# Altium schematic sheet MCU045A_ezFET_Host.SchDoc(MCU045A_ezFET_Host)
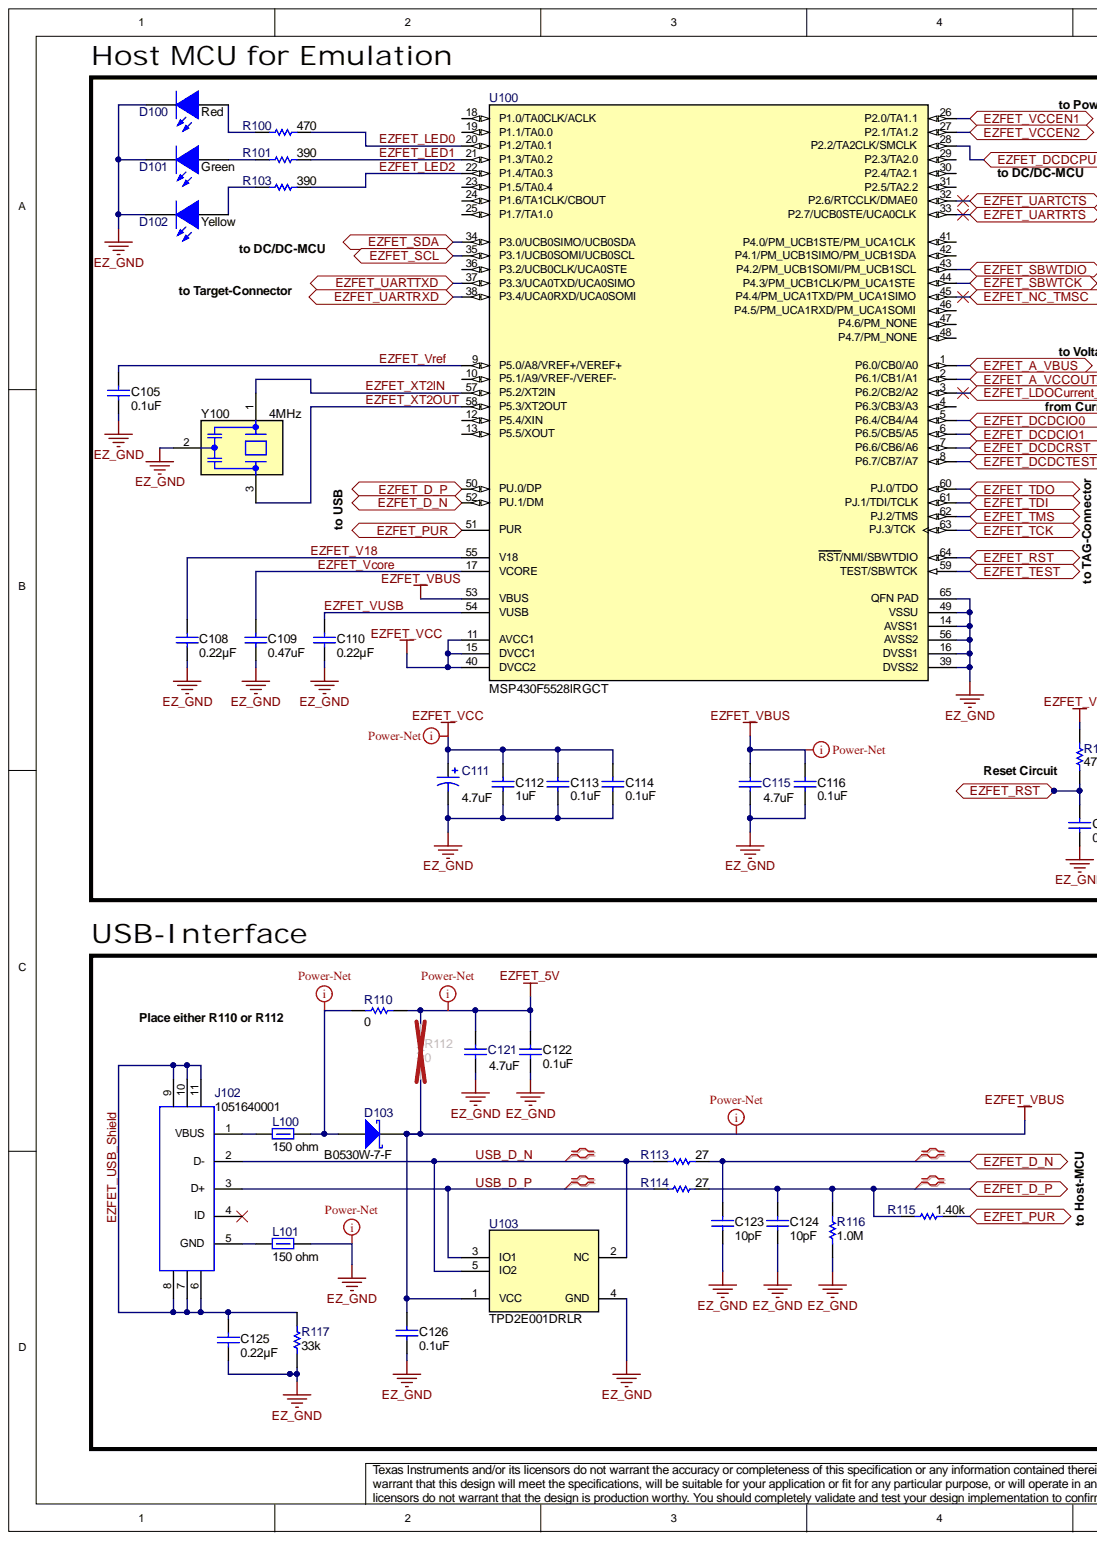
[diagram p0: MCU045A_ezFET_Host.SchDoc, left]
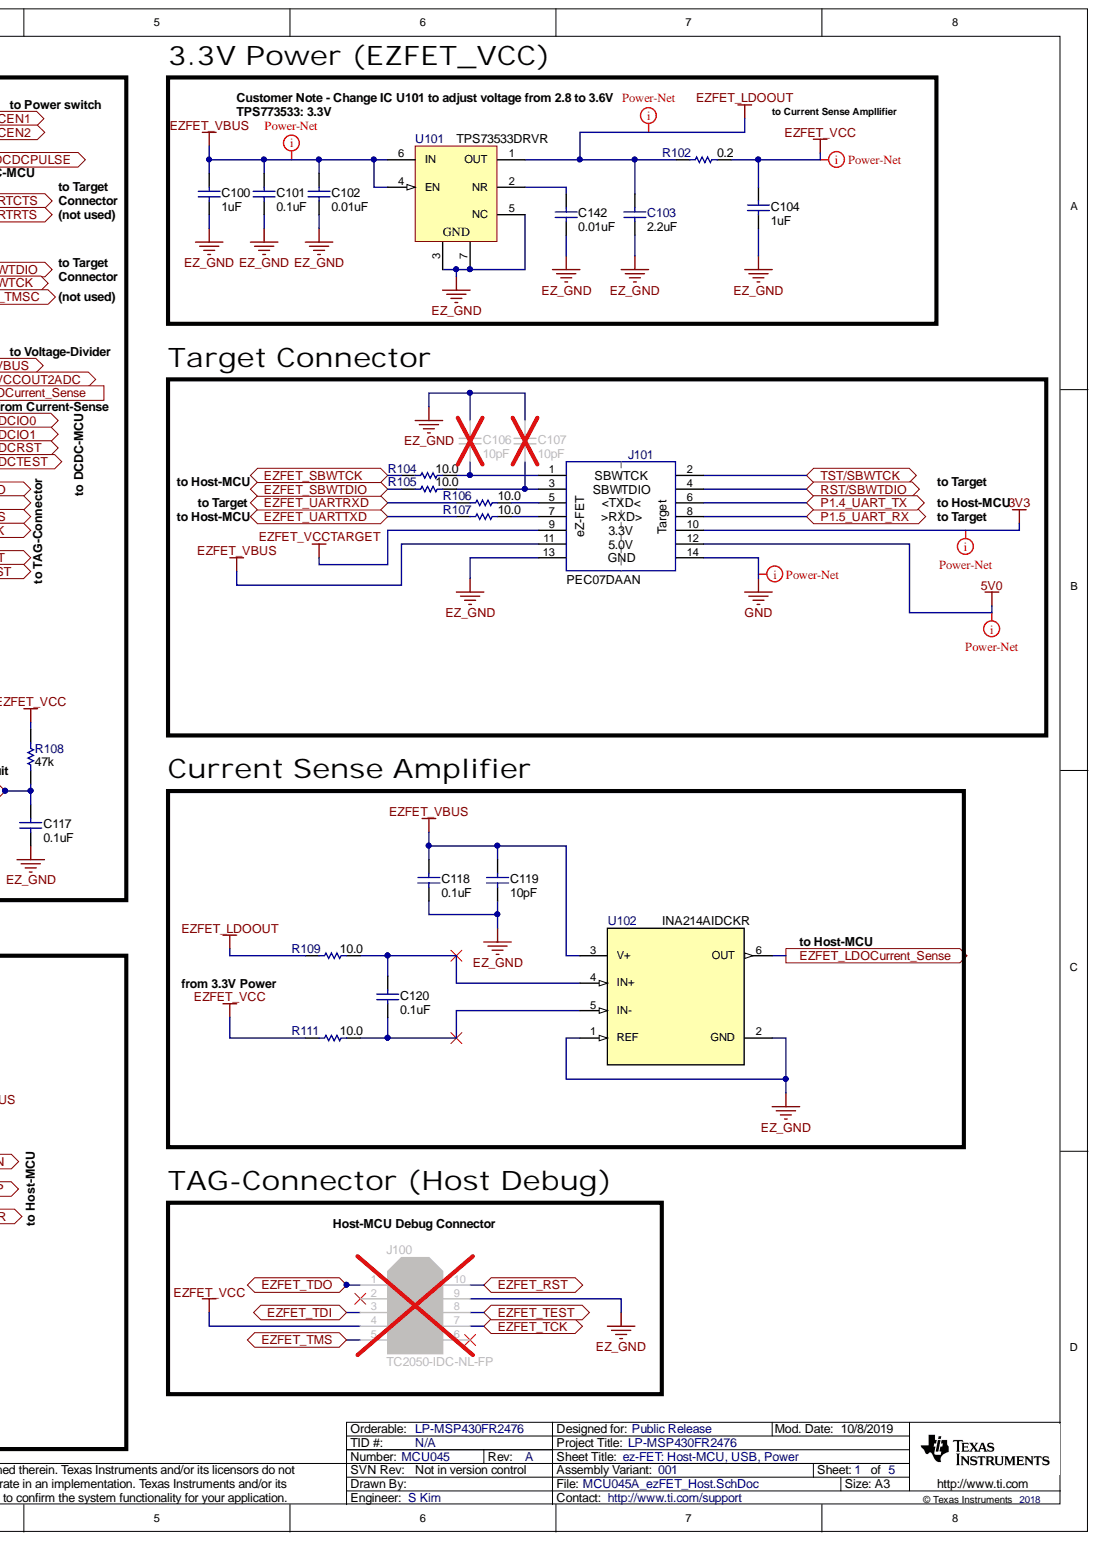
[diagram p1: MCU045A_ezFET_Host.SchDoc, right]

## Parts
C100  value 1uF  ValueDisplayed: 1uF  [0402]  (hidden comment: GRM152R60J105ME15D)
C101  value 0.1uF  ValueDisplayed: 0.1uF  [0402]  (hidden comment: GCM155R71C104KA55D)
C102  value 0.01uF  ValueDisplayed: 0.01uF  [0402]  (hidden comment: 0402ZC103KAT2A)
C103  value 2.2uF  ValueDisplayed: 2.2uF  [0402]  (hidden comment: GRM155R61C225KE11D)
C104  value 1uF  ValueDisplayed: 1uF  [0402]  (hidden comment: GRM152R60J105ME15D)
C105  value 0.1uF  ValueDisplayed: 0.1uF  [0402]  (hidden comment: GCM155R71C104KA55D)
C106  value 10pF  ValueDisplayed: 10pF  [0402]  (hidden comment: 885012005055)
C107  value 10pF  ValueDisplayed: 10pF  [0402]  (hidden comment: 885012005055)
C108  ValueDisplayed: 0.22µF  [0402]  (hidden comment: 885012105011)
C109  value 0.47uF  ValueDisplayed: 0.47uF  [0402]  (hidden comment: 04026D474KAT2A)
C110  ValueDisplayed: 0.22µF  [0402]  (hidden comment: 885012105011)
C111  value 4.7uF  ValueDisplayed: 4.7uF  [3216-18]  (hidden comment: 293D475X9010A2TE3)
C112  value 1uF  ValueDisplayed: 1uF  [0402]  (hidden comment: GRM152R60J105ME15D)
C113  value 0.1uF  ValueDisplayed: 0.1uF  [0402]  (hidden comment: GCM155R71C104KA55D)
C114  value 0.1uF  ValueDisplayed: 0.1uF  [0402]  (hidden comment: GCM155R71C104KA55D)
C115  value 4.7uF  ValueDisplayed: 4.7uF  [0402_065]  (hidden comment: GRM155R60J475ME87D)
C116  value 0.1uF  ValueDisplayed: 0.1uF  [0402]  (hidden comment: GCM155R71C104KA55D)
C117  value 0.1uF  ValueDisplayed: 0.1uF  [0402]  (hidden comment: GMK105BJ104KV-F)
C118  value 0.1uF  ValueDisplayed: 0.1uF  [0402]  (hidden comment: GCM155R71C104KA55D)
C119  value 10pF  ValueDisplayed: 10pF  [0402]  (hidden comment: 885012005055)
C120  value 0.1uF  ValueDisplayed: 0.1uF  [0402]  (hidden comment: GMK105BJ104KV-F)
C121  value 4.7uF  ValueDisplayed: 4.7uF  [0805_HV]  (hidden comment: C0805C475K8PACTU)
C122  value 0.1uF  ValueDisplayed: 0.1uF  [0402]  (hidden comment: GCM155R71C104KA55D)
C123  value 10pF  ValueDisplayed: 10pF  [0402]  (hidden comment: 885012005055)
C124  value 10pF  ValueDisplayed: 10pF  [0402]  (hidden comment: 885012005055)
C125  ValueDisplayed: 0.22µF  [0402]  (hidden comment: 885012105011)
C126  value 0.1uF  ValueDisplayed: 0.1uF  [0402]  (hidden comment: GCM155R71C104KA55D)
C142  value 0.01uF  ValueDisplayed: 0.01uF  [0402]  (hidden comment: 0402ZC103KAT2A)
D100  value Red  [WL-SMCW_RED]  (hidden comment: 150060RS75000)
D101  value Green  [WL-SMCW_GREEN]  (hidden comment: 150060VS75000)
D102  value Yellow  [WL-SMCW_YELLOW]  (hidden comment: 150060YS75000)
D103  B0530W-7-F  [SOD-123]
J100  TC2050-IDC-NL-FP  [Tag_TC2050-NL]  (no BOM)
J101  PEC07DAAN  [PEC07DAAN_Launchpad_EZ-FET_A]
J102  1051640001  [Molex_1051640001]
L100  150 ohm  [0603]
L101  150 ohm  [0603]
R100  value 470  [0402]  (hidden comment: CRCW0402470RJNED)
R101  value 390  [0402]  (hidden comment: CRCW0402390RJNED)
R102  value 0.2  ValueDisplayed: 0.2  [0603]  (hidden comment: CSR0603FKR200)
R103  value 390  [0402]  (hidden comment: CRCW0402390RJNED)
R104  value 10.0  ValueDisplayed: 10.0  [0402]  (hidden comment: CRCW040210R0FKED)
R105  value 10.0  ValueDisplayed: 10.0  [0402]  (hidden comment: CRCW040210R0FKED)
R106  value 10.0  ValueDisplayed: 10.0  [0402]  (hidden comment: CRCW040210R0FKED)
R107  value 10.0  ValueDisplayed: 10.0  [0402]  (hidden comment: CRCW040210R0FKED)
R108  value 47k  ValueDisplayed: 47k  [0402]  (hidden comment: CRCW040247K0JNED)
R109  value 10.0  ValueDisplayed: 10.0  [0402]  (hidden comment: CRCW040210R0FKED)
R110  value 0  [1206]  (hidden comment: CRCW12060000Z0EA)
R111  value 10.0  ValueDisplayed: 10.0  [0402]  (hidden comment: CRCW040210R0FKED)
R112  value 0  [1206]  (hidden comment: CRCW12060000Z0EA)
R113  value 27  [0402]  (hidden comment: CRCW040227R0JNED)
R114  value 27  [0402]  (hidden comment: CRCW040227R0JNED)
R115  value 1.40k  ValueDisplayed: 1.40k  [0402]  (hidden comment: CRCW04021K40FKED)
R116  ValueDisplayed: 1.0M  [0402]  (hidden comment: CRCW04021M00JNED)
R117  value 33k  ValueDisplayed: 33k  [0402]  (hidden comment: CRCW040233K0JNED)
U100  MSP430F5528IRGCT  [RGC0064B]
U101  TPS73533DRVR  [DRV0006A]
U102  INA214AIDCKR  [DCK0006A_N]
U103  TPD2E001DRLR  [DRL0005A]
Y100  Frequency_Fixed: 4MHz  [Murata_CSTCR_G]  (hidden comment: CSTCR4M00G55B-R0)

## Ports
EZFET_A_VBUS, EZFET_A_VCCOUT2ADC, EZFET_D_N, EZFET_D_P, EZFET_DCDCIO0, EZFET_DCDCIO1, EZFET_DCDCPULSE, EZFET_DCDCRST, EZFET_DCDCTEST, EZFET_LDOCurrent_Sense, EZFET_NC_TMSC, EZFET_PUR, EZFET_RST, EZFET_SBWTCK, EZFET_SBWTDIO, EZFET_SCL, EZFET_SDA, EZFET_TCK, EZFET_TDI, EZFET_TDO, EZFET_TEST, EZFET_TMS, EZFET_UARTCTS, EZFET_UARTRTS, EZFET_UARTRXD, EZFET_UARTTXD, EZFET_VCCEN1, EZFET_VCCEN2, P1.4_UART_TX, P1.5_UART_RX, RST/SBWTDIO, TST/SBWTCK

## Nets
3V3: J101.10
5V0: J101.12
EZ_GND: C100.2 C101.2 C102.2 C103.2 C104.2 C105.2 C106.1 C107.1 C108.2 C109.1 C110.2 C111.2 C112.2 C113.2 C114.2 C115.2 C116.2 C117.2 C118.2 C119.2 C121.2 C122.2 C123.2 C124.2 C125.2 C126.2 C142.2 D100.1 D101.1 D102.1 J100.9 J101.13 L101.2 R116.1 R117.1 U100.14(AVSS1) U100.16(DVSS1) U100.39(DVSS2) U100.49(VSSU) U100.56(AVSS2) U100.65(QFN_PAD) U101.3 U101.5(NC) U101.7 U102.1(REF) U102.2(GND) U103.4(GND) Y100.2
EZFET_5V: C121.1 C122.1 R110.1 R112.2
EZFET_LDOOUT: C103.1 R102.1 R109.1 U101.1(OUT)
EZFET_VBUS: C100.1 C101.1 C102.1 C115.1 C116.1 C118.1 C119.1 C126.1 D103.1 J101.11 R112.1 U100.53(VBUS) U101.4(EN) U101.6(IN) U102.3(V+) U103.1(VCC)
EZFET_VCC: C104.1 C111.1 C112.1 C113.1 C114.1 J100.4 R102.2 R108.2 R111.1 U100.11(AVCC1) U100.15(DVCC1) U100.40(DVCC2)
EZFET_VCCTARGET: J101.9
GND: J101.14
EZFET_A_VBUS: U100.1(P6.0/CB0/A0) ; port EZFET_A_VBUS
EZFET_A_VCCOUT2ADC: U100.2(P6.1/CB1/A1) ; port EZFET_A_VCCOUT2ADC
EZFET_D_N: C123.1 R113.2 U100.52(PU.1/DM) ; port EZFET_D_N
EZFET_D_P: C124.1 R114.2 R115.1 R116.2 U100.50(PU.0/DP) ; port EZFET_D_P
EZFET_DCDCIO0: U100.5(P6.4/CB4/A4) ; port EZFET_DCDCIO0
EZFET_DCDCIO1: U100.6(P6.5/CB5/A5) ; port EZFET_DCDCIO1
EZFET_DCDCPULSE: U100.28(P2.2/TA2CLK/SMCLK) ; port EZFET_DCDCPULSE
EZFET_DCDCRST: U100.7(P6.6/CB6/A6) ; port EZFET_DCDCRST
EZFET_DCDCTEST: U100.8(P6.7/CB7/A7) ; port EZFET_DCDCTEST
EZFET_LDOCurrent_Sense: U100.3(P6.2/CB2/A2) U102.6(OUT) ; port EZFET_LDOCurrent_Sense
EZFET_LED0: R100.2 U100.20(P1.2/TA0.1)
EZFET_LED1: R101.2 U100.21(P1.3/TA0.2)
EZFET_LED2: R103.2 U100.22(P1.4/TA0.3)
EZFET_NC_TMSC: U100.45(P4.4/PM_UCA1TXD/PM_UCA1SIMO) ; port EZFET_NC_TMSC
EZFET_PUR: R115.2 U100.51(PUR) ; port EZFET_PUR
EZFET_RST: C117.1 J100.10 R108.1 U100.64(RST/NMI/SBWTDIO) ; port EZFET_RST
EZFET_SBWTCK: R104.1 U100.44(P4.3/PM_UCB1CLK/PM_UCA1STE) ; port EZFET_SBWTCK
EZFET_SBWTDIO: R105.1 U100.43(P4.2/PM_UCB1SOMI/PM_UCB1SCL) ; port EZFET_SBWTDIO
EZFET_SCL: U100.35(P3.1/UCB0SOMI/UCB0SCL) ; port EZFET_SCL
EZFET_SDA: U100.34(P3.0/UCB0SIMO/UCB0SDA) ; port EZFET_SDA
EZFET_TCK: J100.7 U100.63(PJ.3/TCK) ; port EZFET_TCK
EZFET_TDI: J100.3 U100.61(PJ.1/TDI/TCLK) ; port EZFET_TDI
EZFET_TDO: J100.1 U100.60(PJ.0/TDO) ; port EZFET_TDO
EZFET_TEST: J100.8 U100.59(TEST/SBWTCK) ; port EZFET_TEST
EZFET_TMS: J100.5 U100.62(PJ.2/TMS) ; port EZFET_TMS
EZFET_UARTCTS: U100.32(P2.6/RTCCLK/DMAE0) ; port EZFET_UARTCTS
EZFET_UARTRTS: U100.33(P2.7/UCB0STE/UCA0CLK) ; port EZFET_UARTRTS
EZFET_UARTRXD: R106.2 U100.38(P3.4/UCA0RXD/UCA0SOMI) ; port EZFET_UARTRXD
EZFET_UARTTXD: R107.1 U100.37(P3.3/UCA0TXD/UCA0SIMO) ; port EZFET_UARTTXD
EZFET_USB_Shield: C125.1 J102.6 J102.7 J102.8 J102.9 J102.10 J102.11 R117.2
EZFET_V18: C108.1 U100.55(V18)
EZFET_VCCEN1: U100.26(P2.0/TA1.1) ; port EZFET_VCCEN1
EZFET_VCCEN2: U100.27(P2.1/TA1.2) ; port EZFET_VCCEN2
EZFET_Vcore: C109.2 U100.17(VCORE)
EZFET_Vref: C105.1 U100.9(P5.0/A8/VREF+/VEREF+)
EZFET_VUSB: C110.1 U100.54(VUSB)
EZFET_XT2IN: U100.57(P5.2/XT2IN) Y100.1
EZFET_XT2OUT: U100.58(P5.3/XT2OUT) Y100.3
P1.4_UART_TX: J101.6 ; port P1.4_UART_TX
P1.5_UART_RX: J101.8 ; port P1.5_UART_RX
RST/SBWTDIO: J101.4(SBWTDIO) ; port RST/SBWTDIO
TST/SBWTCK: J101.2 ; port TST/SBWTCK
USB_D_N: J102.2(D-) R113.1 U103.2(NC) U103.5(IO2)
USB_D_P: J102.3(D+) R114.1 U103.3(IO1)
NetC106_2: C106.2 J101.1 R104.2
NetC107_2: C107.2 J101.3 R105.2
NetC120_1: C120.1 R109.2 U102.4(IN+)
NetC120_2: C120.2 R111.2 U102.5(IN-)
NetC142_1: C142.1 U101.2(NR)
NetD100_2: D100.2 R100.1
NetD101_2: D101.2 R101.1
NetD102_2: D102.2 R103.1
NetD103_2: D103.2 L100.2 R110.2
NetJ101_5: J101.5 R106.1
NetJ101_7: J101.7 R107.2
NetJ102_1: J102.1(VBUS) L100.1
NetJ102_5: J102.5(GND) L101.1

Not connected: J100 2 6; J102 4(ID); U100 4(P6.3/CB3/A3) 10(P5.1/A9/VREF-/VEREF-) 12(P5.4/XIN) 13(P5.5/XOUT) 18(P1.0/TA0CLK/ACLK) 19(P1.1/TA0.0) 23(P1.5/TA0.4) 24(P1.6/TA1CLK/CBOUT) 25(P1.7/TA1.0) 29(P2.3/TA2.0) 30(P2.4/TA2.1) 31(P2.5/TA2.2) 36(P3.2/UCB0CLK/UCA0STE) 41(P4.0/PM_UCB1STE/PM_UCA1CLK) 42(P4.1/PM_UCB1SIMO/PM_UCB1SDA) 46(P4.5/PM_UCA1RXD/PM_UCA1SOMI) 47(P4.6/PM_NONE) 48(P4.7/PM_NONE)

## Library data of the parts
C100 C104 C112 = CMP-0006372-2: CAP, CERM, 1 uF, 6.3 V, +/- 20%, X5R, 0402; Manufacturer: MuRata; VDC_V: 6.3V; Value1: Unknown; U/M: EA; TaMin_C: -55°C; TaMax_C: 85°C; SizeZ_mm: 0.6; SizeY_mm: 0.5; SizeX_mm: 1; SizeAreaWB_mm2: 3; Polarized: N; CompType: Capacitor; Cap_F: 1µF; AEC Category: Q200; Value2: 6.3V; Tolerance_%: 20%; TempCo: X5R; Technology: Ceramic; Supplier Part Number 2: 81-GRM152R60J105ME5D; Supplier Part Number 1: 490-10006-1-ND; Supplier 2: Mouser; Supplier 1: Digi-Key; SizeArea_mm2: 0.5; RoHS: Y; Published: 2008-06-19; PartNumber: GRM152R60J105ME15D; PackageReference: 0402; MountType: SMT; Library Name: Altium Vault - TI; LatestRevisionDate: 2017-03-09; ComponentLink1URL: http://psearch.murata.com/capacitor/product/GRM152R60J105ME15%23.pdf; ComponentLink1Description: Datasheet link; Component Kind: Standard; Cap_Case: 0402; AEC Qualified: ?; Publisher: Texas Instruments
C101 C105 C113 C114 C116 C118 C122 C126 = CMP-0006351-2: CAP, CERM, 0.1 uF, 16 V, +/- 10%, X7R, 0402; Manufacturer: MuRata; VDC_V: 16V; Value1: Unknown; U/M: EA; TaMin_C: -55°C; TaMax_C: 125°C; SizeZ_mm: 0.6; SizeY_mm: 0.5; SizeX_mm: 1; SizeAreaWB_mm2: 3; Polarized: N; CompType: Capacitor; Cap_F: 100nF; AEC Category: Q200; Value2: 16V; Tolerance_%: 10%; TempCo: X7R; Technology: Ceramic; Supplier Part Number 1: 490-4759-2-ND; Supplier 1: Digi-Key; SizeArea_mm2: 0.5; RoHS: Y; Published: 2008-06-19; PartNumber: GCM155R71C104KA55D; PackageReference: 0402; MountType: SMT; Library Name: Altium Vault - TI; LatestRevisionDate: 2017-03-09; ComponentLink1URL: http://psearch.murata.com/capacitor/product/GCM155R71C104KA55%23.pdf; ComponentLink1Description: Datasheet link; Component Kind: Standard; Cap_Case: 0402; AEC Qualified: ?; Publisher: Texas Instruments
C102 C142 = CMP-0006203-2: CAP, CERM, 0.01 uF, 10 V, +/- 10%, X7R, 0402; Manufacturer: AVX; VDC_V: 10V; Value1: Unknown; U/M: EA; TaMin_C: -55°C; TaMax_C: 125°C; SizeZ_mm: 0.6; SizeY_mm: 0.5; SizeX_mm: 1; SizeAreaWB_mm2: 3; Polarized: N; CompType: Capacitor; Cap_F: 10nF; AEC Category: Q200; Value2: 10V; Tolerance_%: 10%; TempCo: X7R; Technology: Ceramic; Supplier Part Number 3: 581-0402ZC103KAT2A; Supplier Part Number 2: 94W2829; Supplier Part Number 1: 478-7890-1-ND; Supplier 3: Mouser; Supplier 2: Newark; Supplier 1: Digi-Key; SizeArea_mm2: 0.5; RoHS: Y; Published: 2008-06-19; PartNumber: 0402ZC103KAT2A; PackageReference: 0402; MountType: SMT; Library Name: Altium Vault - TI; LatestRevisionDate: 2017-03-09; ComponentLink1URL: http://datasheets.avx.com/X7RDielectric.pdf; ComponentLink1Description: Datasheet link; Component Kind: Standard; Cap_Case: 0402; AEC Qualified: N; AEC Grade: N/A; Publisher: Texas Instruments
C103 = CMP-0006594-2: CAP, CERM, 2.2 uF, 16 V, +/- 10%, X5R, 0402; Manufacturer: MuRata; VDC_V: 16V; Value1: Unknown; U/M: EA; TaMin_C: -55°C; TaMax_C: 85°C; SizeZ_mm: 0.6; SizeY_mm: 0.5; SizeX_mm: 1; SizeAreaWB_mm2: 3; Polarized: N; CompType: Capacitor; Cap_F: 2.2µF; AEC Category: Q200; Value2: 16V; Tolerance_%: 10%; TempCo: X5R; Technology: Ceramic; Supplier Part Number 2: 81-GRM155R61C225KE1D; Supplier Part Number 1: 490-10695-1-ND; Supplier 2: Mouser; Supplier 1: Digi-Key; SizeArea_mm2: 0.5; RoHS: Y; Published: 2008-06-19; PartNumber: GRM155R61C225KE11D; PackageReference: 0402; MountType: SMT; Library Name: Altium Vault - TI; LatestRevisionDate: 2017-03-09; ComponentLink1URL: http://psearch.en.murata.com/capacitor/product/GRM155R61C225KE11%23.pdf; ComponentLink1Description: Datasheet link; Component Kind: Standard; Cap_Case: 0402; AEC Qualified: N; AEC Grade: N/A; Publisher: Texas Instruments
C106 C107 C119 C123 C124 = CMP-0006215-2: CAP, CERM, 10 pF, 50 V, +/- 5%, C0G/NP0, 0402; Manufacturer: Wurth Elektronik; VDC_V: 50V; Value1: Unknown; U/M: EA; TaMin_C: -55°C; TaMax_C: 125°C; SizeZ_mm: 0.6; SizeY_mm: 0.5; SizeX_mm: 1; SizeAreaWB_mm2: 3; Polarized: N; CompType: Capacitor; AEC Category: Q200; Value2: 50V; Tolerance_%: 5%; TempCo: C0G/NP0; Technology: Ceramic; Supplier Part Number 2: 710-885012005055; Supplier Part Number 1: 732-7469-1-ND; Supplier 2: Mouser; Supplier 1: Digi-Key; SizeArea_mm2: 0.5; RoHS: Y; Published: 2008-06-19; PartNumber: 885012005055; PackageReference: 0402; MountType: SMT; Library Name: Altium Vault - TI; LatestRevisionDate: 2017-03-09; ComponentLink1URL: http://katalog.we-online.de/pbs/datasheet/885012005055.pdf; ComponentLink1Description: Datasheet link; Component Kind: Standard; Cap_F: 10pF; Cap_Case: 0402; AEC Qualified: N; AEC Grade: N/A; Publisher: Texas Instruments
C108 C110 C125 = CMP-0078875-1: CAP, CERM, 0.22 µF, 10 V,+/- 20%, X5R, 0402; Manufacturer: Wurth Elektronik; VDC_V: 10V; Value: 0.22uF; U/M: EA; TaMin_C: -55°C; TaMax_C: 85°C; SizeZ_mm: 0.6; SizeY_mm: 0.5; SizeX_mm: 1; SizeAreaWB_mm2: 3; Polarized: N; CompType: Capacitor; Cap_F: 220nF; AEC Category: Q200; Value2: 10V; Tolerance_%: 20%; TempCo: X5R; Technology: Ceramic; Supplier Part Number 2: 710-885012105011; Supplier Part Number 1: 732-7488-1-ND; Supplier 2: Mouser; Supplier 1: Digi-Key; SizeArea_mm2: 0.5; RoHS: Y; PartNumber: 885012105011; PackageReference: 0402; MountType: SMT; Library Name: Altium Vault - TI; ComponentLink1URL: http://katalog.we-online.de/pbs/datasheet/885012105011.pdf; ComponentLink1Description: Datasheet link; Component Kind: Standard; Cap_Case: 0402; AEC Qualified: N; AEC Grade: N/A
C109 = CMP-0006199-2: CAP, CERM, 0.47 uF, 6.3 V, +/- 10%, X5R, 0402; Manufacturer: AVX; VDC_V: 6.3V; Value1: Unknown; U/M: EA; TaMin_C: -55°C; TaMax_C: 85°C; SizeZ_mm: 0.6; SizeY_mm: 0.5; SizeX_mm: 1; SizeAreaWB_mm2: 3; Polarized: N; CompType: Capacitor; Cap_F: 470nF; AEC Category: Q200; Value2: 6.3V; Tolerance_%: 10%; TempCo: X5R; Technology: Ceramic; Supplier Part Number 3: 581-04026D474KAT2A; Supplier Part Number 2: 60R6163; Supplier Part Number 1: 478-6196-1-ND; Supplier 3: Mouser; Supplier 2: Newark; Supplier 1: Digi-Key; SizeArea_mm2: 0.5; RoHS: Y; Published: 2008-06-19; PartNumber: 04026D474KAT2A; PackageReference: 0402; MountType: SMT; Library Name: Altium Vault - TI; LatestRevisionDate: 2017-03-09; ComponentLink1URL: http://catalogs.avx.com/SurfaceMount.pdf; ComponentLink1Description: Datasheet link; Component Kind: Standard; Cap_Case: 0402; AEC Qualified: N; AEC Grade: N/A; Publisher: Texas Instruments
C111 = CMP-0011459-2: CAP, TA, 4.7 uF, 10 V, +/- 10%, 5 ohm, SMD; Manufacturer: Vishay-Sprague; VDC_V: 10V; Value1: 5 ohm; U/M: EA; TaMin_C: -55°C; TaMax_C: 125°C; SizeZ_mm: 1.8; SizeY_mm: 1.6; SizeX_mm: 3.2; SizeAreaWB_mm2: 10.92; SizeArea_mm2: 5.12; Polarized: Y; IRMS_A: 1.2dA; ESR_ohm: 5; CompType: Capacitor; Cap_F: 4.7µF; Cap_Case: A; AEC Category: Q200; Value2: 10V; Tolerance_%: 10%; Technology: TA; Supplier Part Number 3: 197397; Supplier Part Number 2: 74-293D475X9010A2TE3; Supplier Part Number 1: 718-1146-1-ND; Supplier 3: Farnell; Supplier 2: Mouser; Supplier 1: Digi-Key; Series: 293D; RoHS: Y; PartNumber: 293D475X9010A2TE3; PackageReference: 3216-18; MountType: SMT; Library Name: Altium Vault - TI; LatestRevisionNote: Updated supplier links; LatestRevisionDate: 2017-03-09; ComponentLink1URL: http://www.vishay.com/docs/40002/293d.pdf; ComponentLink1Description: Datasheet link; Component Kind: Standard; AEC Qualified: ?; Publisher: Texas Instruments
C115 = CMP-0006553-3: CAP, CERM, 4.7 uF, 6.3 V, +/- 20%, X5R, 0402; Manufacturer: MuRata; VDC_V: 6.3V; Value1: 0.009 ohm; U/M: EA; TaMin_C: -55°C; TaMax_C: 85°C; SizeZ_mm: 0.6; SizeY_mm: 0.5; SizeX_mm: 1; SizeAreaWB_mm2: 3; Polarized: N; IRMS_A: 1.22A; Green_REACh: Incomplete; Green_Lifecycle: Active; ESR_ohm: 9m; CompType: Capacitor; Cap_F: 4.7µF; Cap_Case: 0402; AEC Category: Q200; Value2: 6.3V; Tolerance_%: 20%; TempCo: X5R; Technology: Ceramic; Supplier Part Number 1: 490-5408-1-ND; Supplier 1: Digi-Key; SizeArea_mm2: 0.5; RoHS: Y; PartNumber: GRM155R60J475ME87D; PackageReference: 0402; MountType: SMT; Library Name: Altium Vault - TI; Green_EU RoHS-2: Incomplete; Green_EU RoHS: Passed; ComponentLink1URL: http://www.murata.com/products/catalog/pdf/c02e.pdf; ComponentLink1Description: Datasheet link; Component Kind: Standard; AEC Qualified: N; AEC Grade: N/A
C117 C120 = CMP-0006370-2: CAP, CERM, 0.1 uF, 35 V, +/- 10%, X5R, 0402; Manufacturer: Taiyo Yuden; VDC_V: 35V; Value1: Unknown; U/M: EA; TaMin_C: -55°C; TaMax_C: 85°C; SizeZ_mm: 0.6; SizeY_mm: 0.5; SizeX_mm: 1; SizeAreaWB_mm2: 3; Polarized: N; CompType: Capacitor; Cap_F: 100nF; AEC Category: Q200; Value2: 35V; Tolerance_%: 10%; TempCo: X5R; Technology: Ceramic; Supplier Part Number 3: 963-GMK105BJ104KV-F; Supplier Part Number 2: 84R9117; Supplier Part Number 1: 587-1994-1-ND; Supplier 3: Mouser; Supplier 2: Newark; Supplier 1: Digi-Key; SizeArea_mm2: 0.5; RoHS: Y; Published: 2008-06-19; PartNumber: GMK105BJ104KV-F; PackageReference: 0402; MountType: SMT; Library Name: Altium Vault - TI; LatestRevisionDate: 2017-03-09; ComponentLink1URL: http://www.yuden.co.jp/productdata/catalog/en/mlcc_all_e.pdf; ComponentLink1Description: Datasheet link; Component Kind: Standard; Cap_Case: 0402; AEC Qualified: N; AEC Grade: N/A; Publisher: Texas Instruments
C121 = CMP-0007801-2: CAP, CERM, 4.7 uF, 10 V, +/- 10%, X5R, 0805; Manufacturer: Kemet; VDC_V: 10V; Value1: 0.004 ohm; U/M: EA; TaMin_C: -55°C; TaMax_C: 85°C; SizeZ_mm: 1.45; SizeY_mm: 1.25; SizeX_mm: 2; SizeAreaWB_mm2: 6.75; SizeArea_mm2: 2.5; Polarized: N; IRMS_A: 9.89A; ESR_ohm: 4m; CompType: Capacitor; Cap_F: 4.7µF; Cap_Case: 0805; AEC Category: Q200; Value2: 10V; Tolerance_%: 10%; TempCo: X5R; Technology: Ceramic; Supplier Part Number 3: 80-C0805C475K8P; Supplier Part Number 2: 70K9144; Supplier Part Number 1: 399-3133-1-ND; Supplier 3: Mouser; Supplier 2: Newark; Supplier 1: Digi-Key; RoHS: Y; Published: 2008-06-19; PartNumber: C0805C475K8PACTU; PackageReference: 0805; MountType: SMT; Library Name: Altium Vault - TI; LatestRevisionDate: 2017-03-09; ComponentLink1URL: http://www.kemet.com/kemet/web/homepage/kechome.nsf/file/Partial%20Copy%20-%20Ceramic%20Surface%20Mount%20Capacitors/$file/F3102_CE.pdf; ComponentLink1Description: Datasheet link; Component Kind: Standard; AEC Qualified: ?; Publisher: Texas Instruments
D100 = CMP-0002947-2: LED, Red, SMD; Manufacturer: Wurth Elektronik; Vr_V: 5V; Vf_V: 2V; Value1: 0.072W; U/M: EA; Type: AlInGaP; Tjmax_C: 85°C; Power_TotalModule: 72mW; Io_A: 30mA; Angle: 140; Value2: 2V; Supplier Part Number 3: 710-150060RS75000; Supplier Part Number 2: 95W3795; Supplier Part Number 1: 732-4978-1-ND; Supplier 3: Mouser; Supplier 2: Newark; Supplier 1: Digi-Key; RoHS: Y; Published: 2008-07-11; PartNumber: 150060RS75000; PackageReference: LED_0603; MountType: SMT; Library Name: Altium Vault - TI; LatestRevisionDate: 2017-03-09; CompType: LED; ComponentLink1URL: http://katalog.we-online.de/led/datasheet/150060RS75000.pdf; ComponentLink1Description: Datasheet link; Component Kind: Standard; Color: Red; Publisher: Texas Instruments
D101 = CMP-0002894-1: LED, Green, SMD; Manufacturer: Wurth Elektronik; Vf_V: 2V; Value1: W; U/M: EA; Type: AlInGaP; Io_A: 20mA; Value2: 2V; Supplier Part Number 3: 2322073; Supplier Part Number 2: 95W3797; Supplier Part Number 1: 732-4980-1-ND; Supplier 3: Farnell; Supplier 2: Newark; Supplier 1: Digi-Key; RoHS: Y; Published: 2008-07-11; PartNumber: 150060VS75000; PackageReference: LED_0603; MountType: SMT; Library Name: Altium Vault - TI; LatestRevisionDate: 2017-03-09; CompType: LED; ComponentLink1URL: http://katalog.we-online.de/led/datasheet/150060VS75000.pdf; ComponentLink1Description: Datasheet link; Component Kind: Standard; Color: green; Publisher: Texas Instruments
D102 = CMP-0002892-2: LED, Yellow, SMD; Manufacturer: Wurth Elektronik; Vf_V: 2V; Value1: W; U/M: EA; Type: AlInGaP; Io_A: 20mA; Value2: 2V; Supplier Part Number 3: 2322074; Supplier Part Number 2: 95W3798; Supplier Part Number 1: 732-4981-1-ND; Supplier 3: Farnell; Supplier 2: Newark; Supplier 1: Digi-Key; RoHS: Y; Published: 2008-07-11; PartNumber: 150060YS75000; PackageReference: LED_0603; MountType: SMT; Library Name: Altium Vault - TI; LatestRevisionDate: 2017-03-09; CompType: LED; ComponentLink1URL: http://katalog.we-online.de/led/datasheet/150060YS75000.pdf; ComponentLink1Description: Datasheet link; Component Kind: Standard; Color: yellow; Publisher: Texas Instruments
D103 = CMP-0028643-1: Diode, Schottky, 30 V, 0.5 A, SOD-123; Manufacturer: Diodes Inc.; Vr_V: 30V; Vf_V: 4.3dV; Value2: 0.43V; Value1: 0.5A; Value: 30V; U/M: EA; TJmax_C: 125°C; SizeZ_mm: 1.35; SizeY_mm: 1.7; SizeX_mm: 3.85; SizeAreaWB_mm2: 13.095; SizeArea_mm2: 6.545; Io_A: 5dA; Green_REACh: Passed; Green_Lifecycle: Active; AEC Category: Q101; Type: Schottky; Supplier Part Number 3: 621-B0530W-F; Supplier Part Number 2: 12T1451; Supplier Part Number 1: B0530W-FDICT-ND; Supplier 3: Mouser; Supplier 2: Newark; Supplier 1: Digi-Key; RoHS: Y; Published: 2008-06-26; PartNumber: B0530W-7-F; PackageReference: SOD-123; MountType: SMT; Library Name: Altium Vault - TI; LatestRevisionDate: 2017-05-08; Green_EU RoHS-2: Passed; Green_EU RoHS: Passed; CompType: Diode; ComponentLink1URL: http://www.diodes.com/datasheets/ds30139.pdf; ComponentLink1Description: Datasheet link; Component Kind: Standard; AEC Qualified: ?; Publisher: Texas Instruments
J100 = CMP-0075101-1: Footprint for Tag-Connect TC2050 No-Legs cables; Note: Footprint only, to mate with Tag-Connect TC2050 No-Legs cables; CT: False; CompType: Receptacle; PartNumber: TC2050-IDC-NL-FP; PackageReference: Footprint for TC2050 No Legs series of cables; MountType: N/A; Manufacturer: Tag-Connect; Library Name: Altium Vault - TI; LatestRevisionDate: 2017-07-12; ComponentLink1URL: http://www.tag-connect.com/Materials/TC2050-IDC-430-NL%20Datasheet.pdf; ComponentLink1Description: Datasheet URL; CNHeader: False; Publisher: Texas Instruments
J101 = CMP-0002339-2: Header, 100mil, 7x2, Tin, TH; Manufacturer: Sullins Connector Solutions; Voltage_V: 1.8kV; Shrouded: N; RowSpacing_mm: 2.54; Row_Count: 2; Green_REACh: Passed; Green_Lifecycle: Active; Gender: Male; Current_A: 3A; Cert_UL: Y; Supplier Part Number 1: S2012E-07-ND; Supplier 1: Digi-Key; RoHS: Y; Pitch_mm: 2.54; PinCount: 14; PartNumber: PEC07DAAN; PackageReference: Header, 7x2, 100mil, Tin; Orientation: Vertical; MountType: TH; Library Name: Altium Vault - TI; Green_EU RoHS-2: Passed; Green_EU RoHS: Passed; ContactFinish: Tin; CompType: Header; ComponentLink1URL: http://www.sullinscorp.com/catalogs/77_PAGE108-109_.100_MALE_HDR.pdf; ComponentLink1Description: Datasheet URL; Component Kind: Standard; Color: Black; ClassName: Header
J102 = CMP-0055311-1: Receptacle, USB 2.0, Micro B, 5 Position, R/A, SMT; Manufacturer: Molex; Voltage_V: 30V; Pitch_mm: 0.65; Gender: Female; Current_A: 1.8A; CT: TRUE; ContactFinish: Gold; CNHeader: FALSE; Cert_UL: Y; Supplier Part Number 3: 538-105164-0001; Supplier Part Number 2: 99Y3224; Supplier Part Number 1: WM11263CT-ND; Supplier 3: Mouser; Supplier 2: Newark; Supplier 1: Digi-Key; RoHS: Y; PinCount: 5; PartNumber: 1051640001; PackageReference: Receptacle, USB 2.0, Micro B, 5 Pos, 0.65mm Pitch, R/A, SMT; Orientation: R/A; MountType: SMT; Library Name: Altium Vault - TI; LatestRevisionDate: 26/05/2017; CompType: USB; ComponentLink1URL: http://www.molex.com/pdm_docs/sd/1051640001_sd.pdf; ComponentLink1Description: Datasheet URL; Component Kind: Standard; Color: Black; Publisher: Texas Instruments
L100 L101 = CMP-0081962-1: Ferrite Bead, 150 ohm @ 100 MHz, 0.7 A, 0603; PartNumber: BLM18AG151BH1D; Manufacturer: MuRata; ZTyp@100MHz_Ohm: 150; Value2: 0.7A; Value1: 0.35 ohm; SizeZ_mm: 1; SizeY_mm: 0.8; SizeX_mm: 1.6; SizeAreaWB_mm2: 4.68; SizeArea_mm2: 1.28; Imax_A: 700mA; DCR_ohm: 0.35; ValueDisplayed: 150 ohm; Value: 150 ohm; Supplier Part Number 2: 81-BLM18AG151BH1D; Supplier Part Number 1: 490-14035-1-ND; Supplier 2: Mouser; Supplier 1: Digi-Key; RoHS: Y; PackageReference: 0603; MountType: SMT; Library Name: Altium Vault - TI; CompType: Ferrite Bead; ComponentLink1URL: http://www.murata.com/en-us/products/productdata/8798137286686/QNFA9129.pdf; ComponentLink1Description: Datasheet link; Component Kind: Standard
R100 = CMP-0026934-3: RES, 470, 5%, 0.063 W, AEC-Q200 Grade 0, 0402; Manufacturer: Vishay-Dale; VDC_V: 50V; Value_Code: 470R; Value2: 0.063W; TempCo: 200 ppm/C; SizeZ_mm: 0.6; SizeY_mm: 0.5; SizeX_mm: 1; Power_W: 63mW; EIA_Code: E24; CompType: Resistor; AEC Qualified: Y; AEC Grade: 0; AEC Category: Q200; ValueDisplayed: 470; Value1: 5%; Tolerance_%: 5%; Technology: Thick Film; Supplier Part Number 3: 71-CRCW0402J-470-E3; Supplier Part Number 2: 59M6737; Supplier Part Number 1: 541-470JCT-ND; Supplier 3: Mouser; Supplier 2: Newark; Supplier 1: Digi-Key; SizeArea_mm2: 0.5; RoHS: E; Res_ohm: 470; PartNumber: CRCW0402470RJNED; PackageReference: 0402; MountType: SMT; Library Name: Altium Vault - TI; ComponentLink1URL: http://www.vishay.com/docs/20035/dcrcwe3.pdf; ComponentLink1Description: Datasheet link; Component Kind: Standard
R101 R103 = CMP-0026914-3: RES, 390, 5%, 0.063 W, AEC-Q200 Grade 0, 0402; Manufacturer: Vishay-Dale; VDC_V: 50V; Value_Code: 390R; Value2: 0.063W; TempCo: 200 ppm/C; SizeZ_mm: 0.6; SizeY_mm: 0.5; SizeX_mm: 1; Power_W: 63mW; EIA_Code: E24; CompType: Resistor; AEC Qualified: Y; AEC Grade: 0; AEC Category: Q200; ValueDisplayed: 390; Value1: 5%; Tolerance_%: 5%; Technology: Thick Film; Supplier Part Number 3: 71-CRCW0402J-390-E3; Supplier Part Number 2: 59M6731; Supplier Part Number 1: 541-390JCT-ND; Supplier 3: Mouser; Supplier 2: Newark; Supplier 1: Digi-Key; SizeArea_mm2: 0.5; RoHS: E; Res_ohm: 390; PartNumber: CRCW0402390RJNED; PackageReference: 0402; MountType: SMT; Library Name: Altium Vault - TI; ComponentLink1URL: http://www.vishay.com/docs/20035/dcrcwe3.pdf; ComponentLink1Description: Datasheet link; Component Kind: Standard
R102 = CMP-0022677-3: RES, 0.2, 1%, 0.125 W, 0603; Manufacturer: Stackpole Electronics Inc; VDC_V: 300V; Value_Code: R200; Value2: 0.125W; U/M: EA; TempCo: 25 ppm/C; SizeZ_mm: 1; SizeY_mm: 0.8; SizeX_mm: 1.6; SizeArea_mm2: 1.28; Res_ohm: 2d; Power_W: 125mW; EIA_Code: E96; CompType: Resistor; AEC Qualified: N; AEC Category: Q200; Value1: 1%; Tolerance_%: 1%; Technology: Thick Film; Supplier Part Number 1: CSR0603FKR200CT-ND; Supplier 1: Digi-Key; RoHS: Y; Published: 2008-06-26; PartNumber: CSR0603FKR200; PackageReference: 0603; MountType: SMT; Library Name: Altium Vault - TI; LatestRevisionDate: 2017-03-09; ComponentLink1URL: http://www.seielect.com/catalog/SEI-CSR_CSRN.pdf; ComponentLink1Description: Datasheet link; Component Kind: Standard; AEC Grade: N/A; Publisher: Texas Instruments
R104-R107 R109 R111 = CMP-0025963-3: RES, 10.0, 1%, 0.063 W, AEC-Q200 Grade 0, 0402; Manufacturer: Vishay-Dale; VDC_V: 50V; Value_Code: 10R0; Value2: 0.063W; TempCo: 100 ppm/C; SizeZ_mm: 0.6; SizeY_mm: 0.5; SizeX_mm: 1; Res_ohm: 10; Power_W: 63mW; EIA_Code: E96; CompType: Resistor; AEC Qualified: Y; AEC Grade: 0; AEC Category: Q200; Value1: 1%; Tolerance_%: 1%; Technology: Thick Film; Supplier Part Number 3: 71-CRCW0402-10-E3; Supplier Part Number 2: 52K6600; Supplier Part Number 1: 541-10.0LCT-ND; Supplier 3: Mouser; Supplier 2: Newark; Supplier 1: Digi-Key; SizeArea_mm2: 0.5; RoHS: E; PartNumber: CRCW040210R0FKED; PackageReference: 0402; MountType: SMT; Library Name: Altium Vault - TI; ComponentLink1URL: http://www.vishay.com/docs/20035/dcrcwe3.pdf; ComponentLink1Description: Datasheet link; Component Kind: Standard
R108 = CMP-0026935-3: RES, 47 k, 5%, 0.063 W, AEC-Q200 Grade 0, 0402; Manufacturer: Vishay-Dale; VDC_V: 50V; Value_Code: 47k0; Value2: 0.063W; TempCo: 200 ppm/C; SizeZ_mm: 0.6; SizeY_mm: 0.5; SizeX_mm: 1; Power_W: 63mW; EIA_Code: E24; CompType: Resistor; AEC Qualified: Y; AEC Grade: 0; AEC Category: Q200; Value1: 5%; Tolerance_%: 5%; Technology: Thick Film; Supplier Part Number 3: 71-CRCW0402-J-47K-E3; Supplier Part Number 2: 52K7099; Supplier Part Number 1: 541-47KJCT-ND; Supplier 3: Mouser; Supplier 2: Newark; Supplier 1: Digi-Key; SizeArea_mm2: 0.5; RoHS: E; Res_ohm: 47k; PartNumber: CRCW040247K0JNED; PackageReference: 0402; MountType: SMT; Library Name: Altium Vault - TI; ComponentLink1URL: http://www.vishay.com/docs/20035/dcrcwe3.pdf; ComponentLink1Description: Datasheet link; Component Kind: Standard
R110 R112 = CMP-0018573-3: RES, 0, 5%, 0.25 W, AEC-Q200 Grade 0, 1206; Manufacturer: Vishay-Dale; VDC_V: 200V; Value_Code: 0000; Value2: 0.25W; TempCo: 200 ppm/C; SizeZ_mm: 1.35; SizeY_mm: 1.6; SizeX_mm: 3.2; SizeArea_mm2: 5.12; Power_W: 250mW; EIA_Code: E24; CompType: Resistor; AEC Qualified: Y; AEC Category: Q200; ValueDisplayed: 0; Value1: 5%; Tolerance_%: 5%; Technology: Thick Film; Supplier Part Number 3: 71-CRCW1206-0-E3; Supplier Part Number 2: 53K1777; Supplier Part Number 1: 541-0.0ECT-ND; Supplier 3: Mouser; Supplier 2: Newark; Supplier 1: Digi-Key; RoHS: E; Res_ohm: 0; PartNumber: CRCW12060000Z0EA; PackageReference: 1206; MountType: SMT; Library Name: Altium Vault - TI; ComponentLink1URL: http://www.vishay.com/docs/20035/dcrcwe3.pdf; ComponentLink1Description: Datasheet link; Component Kind: Standard; AEC Grade: 0
R113 R114 = CMP-0026888-3: RES, 27, 5%, 0.063 W, AEC-Q200 Grade 0, 0402; Manufacturer: Vishay-Dale; VDC_V: 50V; Value_Code: 27R0; Value2: 0.063W; TempCo: 200 ppm/C; SizeZ_mm: 0.6; SizeY_mm: 0.5; SizeX_mm: 1; Power_W: 63mW; EIA_Code: E24; CompType: Resistor; AEC Qualified: Y; AEC Grade: 0; AEC Category: Q200; ValueDisplayed: 27; Value1: 5%; Tolerance_%: 5%; Technology: Thick Film; Supplier Part Number 3: 71-CRCW0402J-27-E3; Supplier Part Number 2: 59M6721; Supplier Part Number 1: 541-27JCT-ND; Supplier 3: Mouser; Supplier 2: Newark; Supplier 1: Digi-Key; SizeArea_mm2: 0.5; RoHS: E; Res_ohm: 27; PartNumber: CRCW040227R0JNED; PackageReference: 0402; MountType: SMT; Library Name: Altium Vault - TI; ComponentLink1URL: http://www.vishay.com/docs/20035/dcrcwe3.pdf; ComponentLink1Description: Datasheet link; Component Kind: Standard
R115 = CMP-0026085-3: RES, 1.40 k, 1%, 0.063 W, AEC-Q200 Grade 0, 0402; Manufacturer: Vishay-Dale; VDC_V: 50V; Value_Code: 1k40; Value2: 0.063W; TempCo: 100 ppm/C; SizeZ_mm: 0.6; SizeY_mm: 0.5; SizeX_mm: 1; Res_ohm: 1.4k; Power_W: 63mW; EIA_Code: E96; CompType: Resistor; AEC Qualified: Y; AEC Grade: 0; AEC Category: Q200; Value1: 1%; Tolerance_%: 1%; Technology: Thick Film; Supplier Part Number 3: 71-CRCW0402-1.4K-E3; Supplier Part Number 2: 52K6569; Supplier Part Number 1: 541-1.40KLCT-ND; Supplier 3: Mouser; Supplier 2: Newark; Supplier 1: Digi-Key; SizeArea_mm2: 0.5; RoHS: E; PartNumber: CRCW04021K40FKED; PackageReference: 0402; MountType: SMT; Library Name: Altium Vault - TI; ComponentLink1URL: http://www.vishay.com/docs/20035/dcrcwe3.pdf; ComponentLink1Description: Datasheet link; Component Kind: Standard
R116 = CMP-0026859-2: RES, 1.0 M, 5%, 0.063 W, AEC-Q200 Grade 0, 0402; Manufacturer: Vishay-Dale; VDC_V: 50V; Value_Code: 1M00; Value2: 0.063W; Value: 1.0Meg; TempCo: 200 ppm/C; SizeZ_mm: 0.6; SizeY_mm: 0.5; SizeX_mm: 1; Res_ohm: 1M; Power_W: 63mW; EIA_Code: E24; CompType: Resistor; AEC Qualified: Y; AEC Grade: 0; AEC Category: Q200; Value1: 5%; Tolerance_%: 5%; Technology: Thick Film; Supplier Part Number 3: 71-CRCW0402J-1M-E3; Supplier Part Number 2: 59M6694; Supplier Part Number 1: 541-1.0MJCT-ND; Supplier 3: Mouser; Supplier 2: Newark; Supplier 1: Digi-Key; SizeArea_mm2: 0.5; RoHS: E; PartNumber: CRCW04021M00JNED; PackageReference: 0402; MountType: SMT; Library Name: Altium Vault - TI; ComponentLink1URL: http://www.vishay.com/docs/20035/dcrcwe3.pdf; ComponentLink1Description: Datasheet link; Component Kind: Standard
R117 = CMP-0026907-3: RES, 33 k, 5%, 0.063 W, AEC-Q200 Grade 0, 0402; Manufacturer: Vishay-Dale; VDC_V: 50V; Value_Code: 33k0; Value2: 0.063W; TempCo: 200 ppm/C; SizeZ_mm: 0.6; SizeY_mm: 0.5; SizeX_mm: 1; Power_W: 63mW; EIA_Code: E24; CompType: Resistor; AEC Qualified: Y; AEC Grade: 0; AEC Category: Q200; Value1: 5%; Tolerance_%: 5%; Technology: Thick Film; Supplier Part Number 3: 71-CRCW0402J-33K-E3; Supplier Part Number 2: 59M6727; Supplier Part Number 1: 541-33KJCT-ND; Supplier 3: Mouser; Supplier 2: Newark; Supplier 1: Digi-Key; SizeArea_mm2: 0.5; RoHS: E; Res_ohm: 33k; PartNumber: CRCW040233K0JNED; PackageReference: 0402; MountType: SMT; Library Name: Altium Vault - TI; ComponentLink1URL: http://www.vishay.com/docs/20035/dcrcwe3.pdf; ComponentLink1Description: Datasheet link; Component Kind: Standard
U100 = CMP-0039269-3: 16-Bit Ultra-Low-Power Microcontroller, 128KB Flash, 8KB RAM, USB, 12Bit ADC, 2 USCIs, 32Bit HW MPY, RGC0064B (VQFN-64); Manufacturer: Texas Instruments; Alternate PartNumber: MSP430F5528IRGCR; Watchdog: Yes; USCI_B (I2C & SPI): 2; USB: 1; Timers - 16-bit: 4; SRAM(B): 8192; SizeY_mm: 9; SizeAreaWB_mm2: 121; SizeArea_mm2: 81; Rating: Catalog; Multiplier: 32x32; Green_REACh: Passed; Green_Lifecycle: Active; GPIO: 47; Frequency(MHz): 25; Flash(KB): 128; Eco-Plan: Green (RoHS & no Sb/Br); DMA: 3; CompType: IC; AEC Qualified: N; AEC Category: Q200; ADC Channels: 16; ADC: 12-bit SAR; USCI_A (UART/LIN/IrDA/SPI): 2; Temp Sensor: Yes; SVS: Yes; SizeZ_mm: 1; SizeX_mm: 9; RoHS: Y; Real-Time Clock: Yes; PartNumber: MSP430F5528IRGCT; PackageReference: RGC0064B; PackageDescription: VQFN-64; Operating Temperature Range: -40 to 85 C; MountType: SMT; Library Name: Altium Vault - TI; Green_EU RoHS-2: Passed; Green_EU RoHS: Passed; DatasheetVersion: SLAS590I; ComponentLink3URL: http://www.ti.com/product/msp430f5528/datasheet; ComponentLink3Description: Online Datasheet; ComponentLink2URL: http://www.ti.com/product/msp430f5528; ComponentLink2Description: Product Folder; ComponentLink1URL: http://www.ti.com/lit/ds/symlink/msp430f5528.pdf; ComponentLink1Description: Datasheet Link; Component Kind: Standard; Comparators: Yes; Brown Out Reset: Yes; Alternate Manufacturer: Texas Instruments
U101 = CMP-0036330-5: Single Output High PSRR LDO, 500 mA, Fixed 3.3 V Output, 2.7 to 6.5 V Input, with Low IQ, 6-pin SON (DRV), -40 to 125 degC, Green (RoHS & no Sb/Br); Manufacturer: Texas Instruments; Vout Adj(Min)(V): 3.3; Vin(Min)(V): 2.7; Vin(Max)(V): 6.5; Vdo(Typ)(mV): 280; SubFamily: Single Channel LDO; SizeZ_mm: 0.8; SizeY_mm: 2; SizeAreaWB_mm2: 9; SizeArea_mm2: 4; Iq(Typ)(mA): 0.04; Iout(Max)(A): 0.5; CompType: IC; Vout Adj(Max)(V): 3.3; SizeX_mm: 2; PartNumber: TPS73533DRVR; PackageVersion: 4206925/E; PackageReference: DRV0006A; PackageDescription: WSON-6; MountType: SMT; Mounting Technology: Surface Mount; Library Name: Altium Vault - TI; Fixed Output Options(V): 3.3; Eco-Plan: Green (RoHS & no Sb/Br); DatasheetVersion: SBVS087J; ComponentLink3URL: http://www.ti.com/litv/pdf/mpds216d; ComponentLink3Description: Package Specification; ComponentLink2URL: http://www.ti.com/general/docs/lit/getliterature.tsp?genericPartNumber=TPS73533&fileType=pdf; ComponentLink2Description: Datasheet; ComponentLink1URL: http://www.ti.com/; ComponentLink1Description: Manufacturer URL; Component Kind: Standard; Accuracy(%): 2
U102 = CMP-0077332-1: Voltage Output, High or Low Side Measurement, Bi-Directional Zerø-Drift Series Current-Shunt Monitor, DCK0006A (SOT-SC70-6); Manufacturer: Texas Instruments; Alternate PartNumber: INA214AIDCKT; U/M: EA; SizeZ_mm: 1.1; SizeY_mm: 2; SizeX_mm: 2.1; SizeAreaWB_mm2: 9.3; SizeArea_mm2: 4.2; CompType: IC; RoHS: Y; PartNumber: INA214AIDCKR; PackageReference: DCK0006A; PackageDescription: SOT-SC70-6; MountType: SMT; Library Name: Altium Vault - TI; LatestRevisionDate: 2017-08-22; ComponentLink1URL: http://www.ti.com/lit/ds/symlink/.pdf; ComponentLink1Description: Datasheet Link; Component Kind: Standard; Alternate Manufacturer: Texas Instruments; Publisher: Texas Instruments
U103 = CMP-0043096-2: Low-Capacitance + / - 15 kV ESD-Protection Array for High-Speed Data Interfaces, 2 Channels, -40 to +85 degC, 5-pin SOT (DRL), Green (RoHS & no Sb/Br); Manufacturer: Texas Instruments; USB High Speed: TRUE; SubFamily: ESD Solution; IO Leakage Current(nA): 1; IO Capacitance(Typ)(pF): 1.5; IEC 61000-4-2 Contact(+/- kV): 8; IEC 61000-4-2 Air-Gap(+/- kV): 15; Breakdown Voltage(Min)(V): 11; PartNumber: TPD2E001DRLR; PackageVersion: revD, Aug-2007; PackageReference: DRL0005A; PackageDescription: 5-Pin Plastic Small Outline, Flat Lead 0.5 mm Pitch; Mounting Technology: Surface Mount; Library Name: Altium Vault - TI; Eco-Plan: Green (RoHS & no Sb/Br); DatasheetVersion: SLLS684F; ComponentLink3URL: SamplesURL:http://www.ti.com/product/tpd2e001#samples; ComponentLink3Description: URL Link1; ComponentLink2URL: http://www.ti.com/general/docs/lit/getliterature.tsp?genericPartNumber=TPD2E001&fileType=pdf; ComponentLink2Description: Datasheet; ComponentLink1URL: http://www.ti.com/; ComponentLink1Description: Manufacturer URL; Component Kind: Standard
Y100 = CMP-0084387-1: Resonator, 4 MHz, 39 pF, AEC-Q200 Grade 1, SMD; Manufacturer: MuRata; TaMin_C: -40°C; TaMax_C: 125°C; SizeZ_mm: 1.2; SizeY_mm: 2; SizeX_mm: 4.5; SizeAreaWB_mm2: 16.5; SizeArea_mm2: 9; Load Capacitance: 39 pF; AEC Qualified: Y; AEC Grade: 1; AEC Category: Q200; RoHS: Y; PartNumber: CSTCR4M00G55B-R0; PackageReference: 4.5x1.2x2 mm; MountType: SMT; Library Name: Altium Vault - TI; CompType: Resonator; ComponentLink1URL: https://www.murata.com/~/media/webrenewal/support/library/catalog/products/timingdevice/ceralock/p16e.ashx; ComponentLink1Description: Datasheet Link; Component Kind: Standard
